# Altium schematic sheet TopSheet.SchDoc
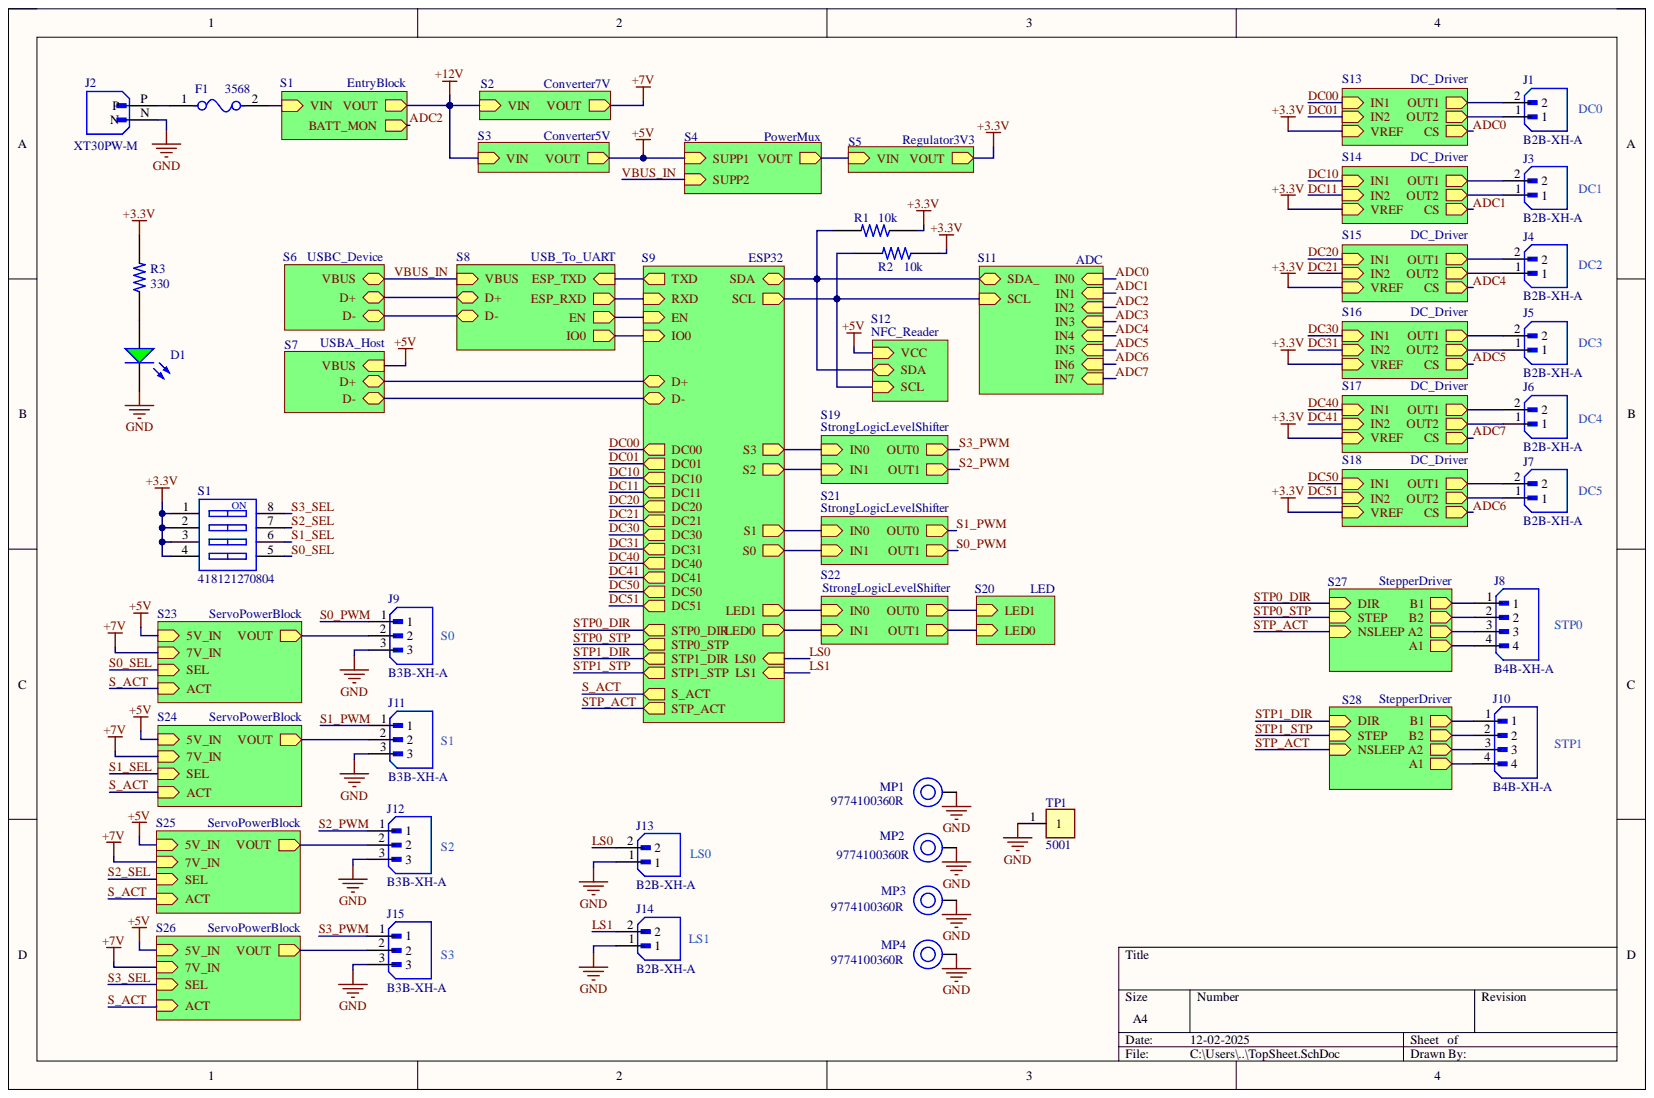
[diagram p0: TopSheet.SchDoc]

## Parts
D1  150060GS75000  [WL-SMCW_0603_150060xx75000]  (value not drawn)
F1  3568  [FP-3568-MFG]
J1  B2B-XH-A  [JST_B2B-XH-A]
J2  XT30PW-M  [AMASS_XT30PW-M]
J3  B2B-XH-A  [JST_B2B-XH-A]
J4  B2B-XH-A  [JST_B2B-XH-A]
J5  B2B-XH-A  [JST_B2B-XH-A]
J6  B2B-XH-A  [JST_B2B-XH-A]
J7  B2B-XH-A  [JST_B2B-XH-A]
J8  B4B-XH-A  [JST_B4B-XH-A]
J9  B3B-XH-A  [JST_B3B-XH-A]
J10  B4B-XH-A  [JST_B4B-XH-A]
J11  B3B-XH-A  [JST_B3B-XH-A]
J12  B3B-XH-A  [JST_B3B-XH-A]
J13  B2B-XH-A  [JST_B2B-XH-A]
J14  B2B-XH-A  [JST_B2B-XH-A]
J15  B3B-XH-A  [JST_B3B-XH-A]
MP1  9774100360R  [9774100360]
MP2  9774100360R  [9774100360]
MP3  9774100360R  [9774100360]
MP4  9774100360R  [9774100360]
R1  value 10k  [FP-R0402]  (hidden comment: RC0402FR-0710KL)
R2  value 10k  [FP-R0402]  (hidden comment: RC0402FR-0710KL)
R3  value 330  [FP-C0603]  (hidden comment: RC0603FR-07330RL)
S1  418121270804  [418121270804]
TP1  5001  [FP-5001-MFG]

## Nets
+3.3V: R1.1 R2.1 R3.2 S1.1 S1.2 S1.3 S1.4
GND: D1.1 J2.N J9.3 J11.3 J12.3 J13.1 J14.1 J15.3 MP1.1 MP2.1 MP3.1 MP4.1 TP1.1
LS0: J13.2
LS1: J14.2
S0_PWM: J9.1
S0_SEL: S1.5
S1_PWM: J11.1
S1_SEL: S1.6
S2_PWM: J12.1
S2_SEL: S1.7
S3_PWM: J15.1
S3_SEL: S1.8
NetD1_2: D1.2 R3.1
NetF1_1: F1.1 J2.P
NetF1_2: F1.2
NetJ1_1: J1.1
NetJ1_2: J1.2
NetJ3_1: J3.1
NetJ3_2: J3.2
NetJ4_1: J4.1
NetJ4_2: J4.2
NetJ5_1: J5.1
NetJ5_2: J5.2
NetJ6_1: J6.1
NetJ6_2: J6.2
NetJ7_1: J7.1
NetJ7_2: J7.2
NetJ8_1: J8.1
NetJ8_2: J8.2
NetJ8_3: J8.3
NetJ8_4: J8.4
NetJ9_2: J9.2
NetJ10_1: J10.1
NetJ10_2: J10.2
NetJ10_3: J10.3
NetJ10_4: J10.4
NetJ11_2: J11.2
NetJ12_2: J12.2
NetJ15_2: J15.2
NetR1_2: R1.2
NetR2_2: R2.2
+5V:
+7V:
+12V:
ADC0:
ADC1:
ADC2:
ADC3:
ADC4:
ADC5:
ADC6:
ADC7:
D+:
D-:
DC00:
DC01:
DC10:
DC11:
DC20:
DC21:
DC30:
DC31:
DC40:
DC41:
DC50:
DC51:
EN:
ESP_RXD:
ESP_TXD:
IN0:
IN1:
IO0:
LED0:
LED1:
S_ACT:
STP0_DIR:
STP0_STP:
STP1_DIR:
STP1_STP:
STP_ACT:
VBUS_IN:
VIN:

## Library data of the parts
D1 = CMP-007-00038-1: Single Color LED, Green, Water Clear, 1.1mm; Channels: 1; Forward Current: 30mA; Luminous Intensity-Nom: 430mcd; Overall Height: 0.7mm; Shape: Rectangular; Viewing Angle: 140°; Color: Green; Component Kind: Standard; Lens Style: WATER CLEAR; Library Name: Machine PM; Max Operating Temperature: 85°C; Min Operating Temperature: -40°C; Mounting Technology: Surface Mount; Optoelectronic Device Type: SINGLE COLOR LED; Packaging: Tape and Reel; Pins: 2; Reach SVHC Compliant: Yes; RoHS Compliant: Yes; Size: 1.1mm; Terminal Pitch: 1.6mm
F1 = CMP-00030-00002-1: Fuses/Accessories; Component Kind: Standard; Library Name: Machine PM
J1 J3-J7 J13 J14 = CMP-046-000000-2: MF: {'manufacturer': u'JST Sales America Inc.'}; Availability: Unavailable; Component Kind: Standard; Component Type: Standard; Design Item ID: B2B-XH-A; Library Name: Machine PM; MP: B2B-XH-A; Package: None; Pin Count: 2; Price: None; Source: B2B-XH-A.SchLib
J2 = CMP-002-00091-3: Socket; DC supply; XT30; male; PIN: 2; on PCBs; THT; Colour: yellow; MF: AMASS; Case/Package: None; Check_prices: https://www.snapeda.com/parts/XT30PW-M/AMASS/view-part/?ref=eda; Component Kind: Standard; Component Type: Standard; Design Item ID: XT30PW-M; Library Name: Machine PM; MP: XT30PW-M; Pins: 2; SnapEDA_Link: https://www.snapeda.com/parts/XT30PW-M/AMASS/view-part/?ref=snap; Source: XT30PW-M.SchLib
J8 J10 = CMP-002-00098-2: Connector Header Through Hole 4 position 0.098 (2.50mm); MF: JST Sales; Case/Package: None; Check_prices: https://www.snapeda.com/parts/B4B-XH-A/JST/view-part/?ref=eda; Component Kind: Standard; Component Type: Standard; Design Item ID: B4B-XH-A; Library Name: Machine PM; MP: B4B-XH-A; Pins: 4; SnapEDA_Link: https://www.snapeda.com/parts/B4B-XH-A/JST/view-part/?ref=snap; Source: B4B-XH-A.SchLib
J9 J11 J12 J15 = CMP-002-00098-1: Connector Header Through Hole 3 position 0.098 (2.50mm); MF: JST Sales; Case/Package: None; Check_prices: https://www.snapeda.com/parts/B3B-XH-A/JST+Sales+America+Inc./view-part/?ref=eda; Component Kind: Standard; Component Type: Connectors; Design Item ID: B3B-XH-A; MP: B3B-XH-A; Pins: 3; SnapEDA_Link: https://www.snapeda.com/parts/B3B-XH-A/JST+Sales+America+Inc./view-part/?ref=snap; Source: B3B-XH-A.SchLib; Library Name: PolyOrbite - Rover - Electrique
MP1-MP4 = CMP-339-000003-2: 9774100360R WA-SMSI SMT Steel Spacer, M3 Internal non Stop; NexarPartId: 39399851; Outside Diameter: 5.9944mm; Plating: Tin; Threaded/Unthreaded: Threaded; Component Kind: Standard; Component Type: Standard; Design Item ID: CMP-03913-39399851-1; Length: 10mm; Material: Steel; Max Operating Temperature: 150°C; Min Operating Temperature: -55°C; Mount: External; Packaging: Tape and Reel; Pin Count: 1; REACH SVHC: Unknown; RoHS: Compliant; Source: PCB1_A25.SCHLIB; Thread Size: M3; Width: 6mm; Library Name: PolyOrbite - Rover - Electrique
R1 R2 = CMP-009-00219-5: Fixed Resistor, Metal Glaze/thick Film, 0.0625W, 10000ohm, 50V, 1% +/-Tol, 100ppm/Cel, Surface Mount, 0402; Power: 62.5mW; Case/Package: 0402; Component Kind: Standard; Library Name: Machine PM; Max Operating Temperature: 155°C; Min Operating Temperature: -55°C; Mounting Technology: Surface Mount; Pins: 2; RoHS Compliant: Yes; Tolerance: 1%
R3 = CMP-009-00206-3: 330 Ohms Â±1% 0.1W, 1/10W Chip Resistor 0603 (1608 Metric) Moisture Resistant Thick Film; MF: Yageo; Case/Package: 0603 Yageo; Check_prices: https://www.snapeda.com/parts/RC0603FR-07330RL/Yageo/view-part/?ref=eda; Component Kind: Standard; Component Type: Standard; Design Item ID: RC0603FR-07330RL; Library Name: Machine PM; MP: RC0603FR-07330RL; Pins: 2; SnapEDA_Link: https://www.snapeda.com/parts/RC0603FR-07330RL/Yageo/view-part/?ref=snap; Source: RC0603FR-07330RL.SchLib
S1 = CMP-1438-00004-3: NexarPartId: 29930312; Body Material: Polyphenylene; Contact Current Rating: 25mA; Contact Resistance: 50mΩ; Electrical Life: 2kcycles; Insulation Resistance: 100MΩ; Mechanical Life: 5kcycles; Number of Circuits: 4; Pitch: 2.54mm; Throw Configuration: SPST; Voltage Rating: 24V; Washable: No; Component Kind: Standard; Contact Plating: Gold; Current Rating: 25mA; Height: 4.62mm; Length: 11.1mm; Library Name: Altium Content Vault; Life (Cycles): 2kcycles; Max Operating Temperature: 85°C; Min Operating Temperature: -40°C; Mount: Surface Mount; Number of Positions: 4; Packaging: Tape and Reel; RoHS: Compliant; Termination: Gull Wing; Voltage Rating (DC): 24V
TP1 = CMP-162-000001-2: MS27478 - Connectors Receptacle Electrical Solder Mounting Solder Type Hermetic Seal 38999 Series II; Manufacturer: Keystone; Component Kind: Standard; Component Type: Miscellaneous; Design Item ID: CMP-00030-00005-1; Manufacturer Part Number: 5001; Mounting Technology: PCB, Through Hole; Pin Count: 1; Pins: 1; Source: PCB1_A25.SCHLIB; Library Name: PolyOrbite - Rover - Electrique
